# Altium schematic sheet SCH.SchDoc
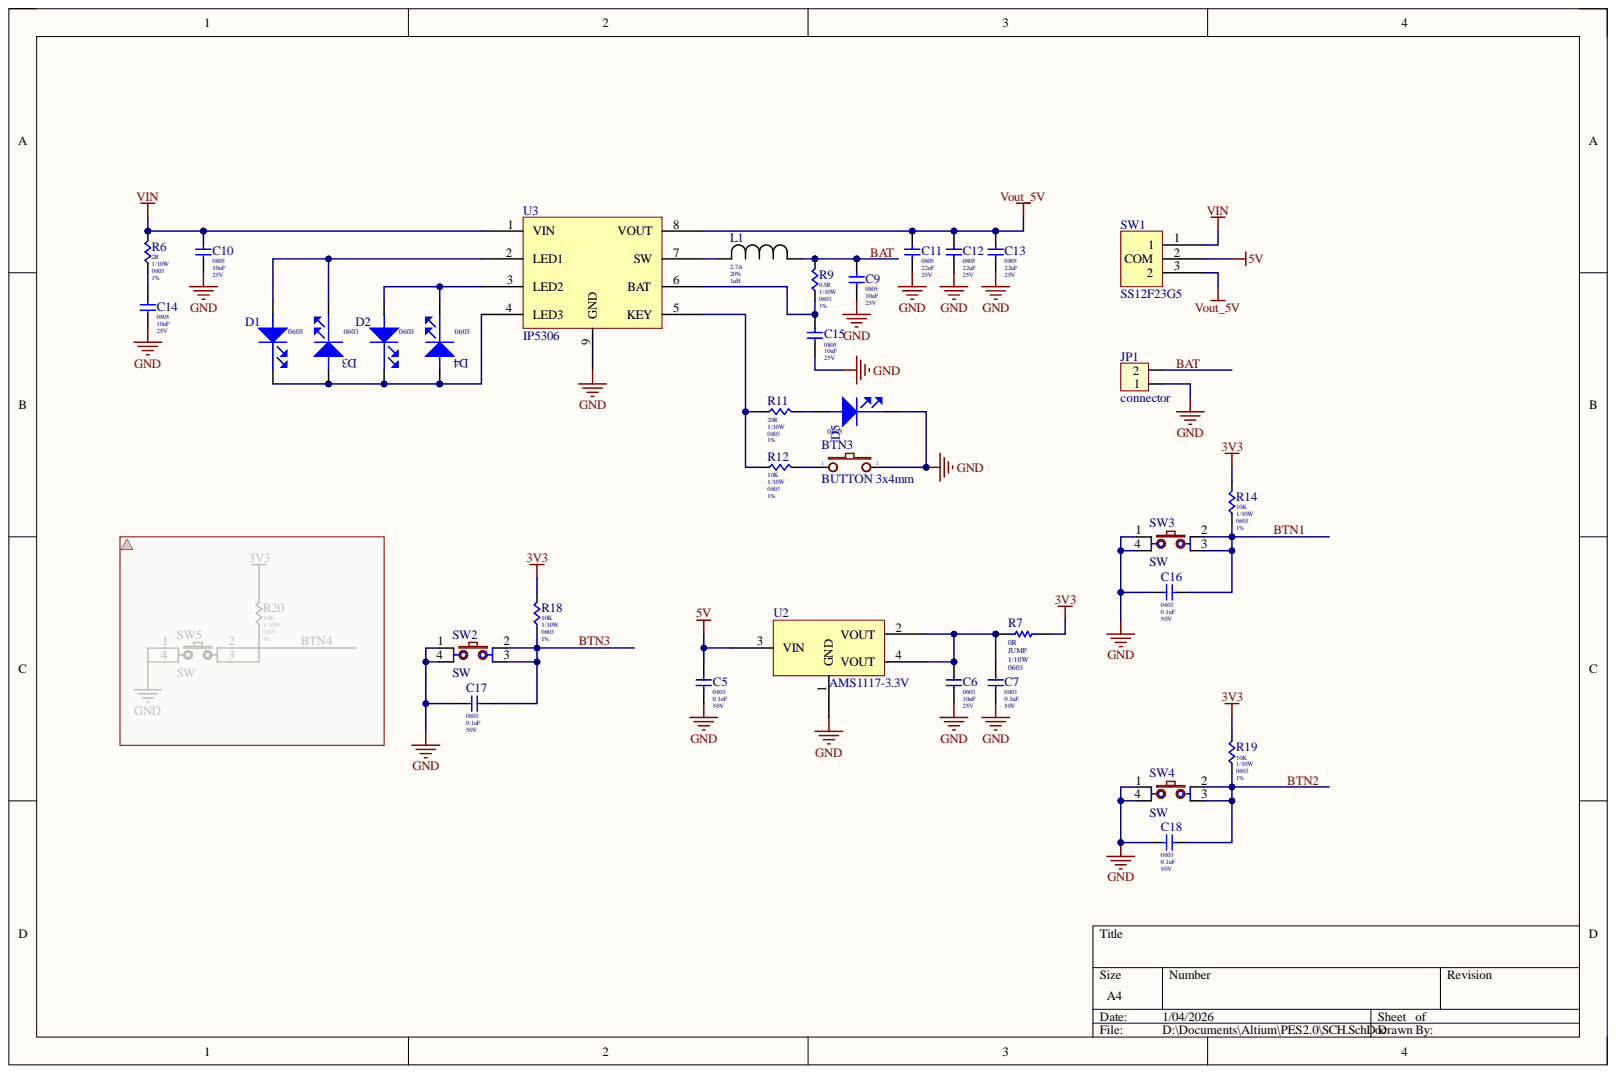
[diagram p0: SCH.SchDoc]

## Parts
BTN3  BUTTON 3x4mm  [BUTTON 3x4mm]
C5  value 0.1uF  Package: 0603  Voltage: 50V  [Cap_0603]  (hidden comment: CL10B104KB8NNNC)
C6  value 10uF  Package: 0603  Voltage: 25V  [Cap_0603]  (hidden comment: CL10A106MA8NRNC)
C7  value 0.1uF  Package: 0603  Voltage: 50V  [Cap_0603]  (hidden comment: CL10B104KB8NNNC)
C9  value 10uF  Package: 0805  Voltage: 25V  [Cap_0805]  (hidden comment: CL21A106KAYNNNE)
C10  value 10uF  Package: 0805  Voltage: 25V  [Cap_0805]  (hidden comment: CL21A106KAYNNNE)
C11  value 22uF  Package: 0805  Voltage: 25V  [Cap_0805]  (hidden comment: CL21A226MAYNNNE)
C12  value 22uF  Package: 0805  Voltage: 25V  [Cap_0805]  (hidden comment: CL21A226MAYNNNE)
C13  value 22uF  Package: 0805  Voltage: 25V  [Cap_0805]  (hidden comment: CL21A226MAYNNNE)
C14  value 10uF  Package: 0805  Voltage: 25V  [Cap_0805]  (hidden comment: CL21A106KAYNNNE)
C15  value 10uF  Package: 0805  Voltage: 25V  [Cap_0805]  (hidden comment: CL21A106KAYNNNE)
C16  value 0.1uF  Package: 0603  Voltage: 50V  [Cap_0603]  (hidden comment: CL10B104KB8NNNC)
C17  value 0.1uF  Package: 0603  Voltage: 50V  [Cap_0603]  (hidden comment: CL10B104KB8NNNC)
C18  value 0.1uF  Package: 0603  Voltage: 50V  [Cap_0603]  (hidden comment: CL10B104KB8NNNC)
D1  Package: 0603  [LED_0603_GREEN]  (hidden comment: 150060GS75000)
D2  Package: 0603  [LED_0603_GREEN]  (hidden comment: 150060GS75000)
D3  Package: 0603  [LED_0603_GREEN]  (hidden comment: 150060GS75000)
D4  Package: 0603  [LED_0603_GREEN]  (hidden comment: 150060GS75000)
D5  Package: 0603  [LED_0603_GREEN]  (hidden comment: 150060GS75000)
JP1  connector  [CON2]
L1  Current: 2.7A  Inductance: 1uH  Tolerance: 20%  [IND_CD43 4532-1R0]  (hidden comment: CD43 4532-1R0)
R6  value 2R  Pakage: 0603  Power: 1/10W  Tolerance: 1%  [RESC1608X05N]  (hidden comment: RMCF0603FT2R00)
R7  Resistance: 0R  Tolerance: JUMP  [RESC1608X05N]  (hidden comment: RC0603JR-070RL)
R9  0.5R  Pakage: 0603  Power: 1/10W  Tolerance: 1%  [RESC1608X05N]
R11  value 20R  Pakage: 0603  Power: 1/10W  [RESC1608X05N]  (hidden comment: RMCF0603FT20R0)
R12  value 10K  Package: 0603  Power: 1/10W  Tolerance: 1%  [RESC1608X05N]  (hidden comment: RC0603FR-0710KL)
R14  value 10K  Package: 0603  Power: 1/10W  Tolerance: 1%  [RESC1608X05N]  (hidden comment: RC0603FR-0710KL)
R18  value 10K  Package: 0603  Power: 1/10W  Tolerance: 1%  [RESC1608X05N]  (hidden comment: RC0603FR-0710KL)
R19  value 10K  Package: 0603  Power: 1/10W  Tolerance: 1%  [RESC1608X05N]  (hidden comment: RC0603FR-0710KL)
SW1  SS12F23G5  [SW_SS12F23G5]
SW2  SW  [SW2-SMD]
SW3  SW  [SW2-SMD]
SW4  SW  [SW2-SMD]
U2  AMS1117-3.3V  [SOT223]
U3  IP5306  [IC_IP5306]

## Nets
3V3: R7.2 R14.2 R18.2 R19.2
5V: C5.2 SW1.2 U2.3(VIN)
GND: BTN3.2 C5.1 C6.1 C7.1 C9.1 C10.1 C11.1 C12.1 C13.1 C14.1 C15.1 C16.2 C17.2 C18.2 D5.1 JP1.1 SW2.1 SW2.4 SW3.1 SW3.4 SW4.1 SW4.4 U2.1(GND) U3.9(GND)
VIN: C10.2 R6.2 SW1.1 U3.1(VIN)
Vout_5V: C11.2 C12.2 C13.2 SW1.3 U3.8(VOUT)
BAT: C9.2 JP1.2 L1.1 R9.2
BTN1: C16.1 R14.1 SW3.2 SW3.3
BTN2: C18.1 R19.1 SW4.2 SW4.3
BTN3: C17.1 R18.1 SW2.2 SW2.3
NetBTN3_1: BTN3.1 R12.2
NetC6_2: C6.2 C7.2 R7.1 U2.2(VOUT) U2.4(VOUT)
NetC14_2: C14.2 R6.1
NetC15_2: C15.2 R9.1 U3.6(BAT)
NetD1_1: D1.1 D2.1 D3.2 D4.2 U3.4(LED3)
NetD1_2: D1.2 D3.1 U3.2(LED1)
NetD2_2: D2.2 D4.1 U3.3(LED2)
NetD5_2: D5.2 R11.2
NetL1_2: L1.2 U3.7(SW)
NetR11_1: R11.1 R12.1 U3.5(KEY)

## Library data of the parts
C5 C7 C16-C18 = Cap Cer_0.1uF_50V_X7R_0603: CAP CER 0.1UF 50V X7R 0603; Component Kind: Standard; ComponentLink1Description: CXT; ComponentLink1URL: https://linhkien.cxtvn.com/1981-tu-0603-khong-phan-cuc.html; ComponentLink2Description: DIGIKEY; ComponentLink2URL: https://www.digikey.com/en/products/detail/samsung-electro-mechanics/CL10B104KB8NNNC/3886658; Library Name: HungKings.IntLib
C6 = Cap Cer_10uF_25V_X5R_0603: CAP CER 10UF 25V X5R 0603; Component Kind: Standard; ComponentLink1Description: CXT; ComponentLink1URL: https://linhkien.cxtvn.com/2886-tu-0603-khong-phan-cuc.html; ComponentLink2Description: DIGIKEY; ComponentLink2URL: https://www.digikey.com/en/products/detail/samsung-electro-mechanics/CL10A106MA8NRNC/3887527; Library Name: HungKings.IntLib
C9 C10 C14 C15 = Cap Cer_10uF_25V_X5R_0805: CAP CER 10UF 25V X5R 0805; Component Kind: Standard; ComponentLink1Description: CXT; ComponentLink1URL: https://linhkien.cxtvn.com/1978-tu-khong-phan-cuc-0805.html; ComponentLink2Description: DIGIKEY; ComponentLink2URL: https://www.digikey.bg/en/products/detail/samsung-electro-mechanics/CL21A106KAYNNNE/3888549?s=N4IgTCBcDaIMIBkwEYCCyAMA2A0qgmgHLECiIAugL5A; Library Name: HungKings.IntLib
C11-C13 = Cap Cer_22uF_25V_X5R_0805: CAP CER 22UF 25V X5R 0805; Component Kind: Standard; ComponentLink1Description: LKCL; ComponentLink1URL: https://linhkienchatluong.vn/tu-dan-0805/tu-dan-0805-226-22uf-25v-20-10c_sp1293_ct16943.aspx; ComponentLink2Description: DIGIKEY; ComponentLink2URL: https://www.digikey.com/en/products/detail/samsung-electro-mechanics/CL21A226MAYNNNE/10479857; Library Name: HungKings.IntLib
D1-D5 = LED_0603_Green: LED YELLOW/GREEN CLEAR 0603 SMD; Manufacturer: Würth Elektronik; Component Kind: Standard; ComponentLink1Description: BLK; ComponentLink1URL: https://banlinhkien.com/led-0603-mau-xanh-la-green-10-chiec-p22456389.html; ComponentLink2Description: DIGIKEY; ComponentLink2URL: https://www.digikey.bg/en/products/detail/w%C3%BCrth-elektronik/150060GS75000/4489898; Manufacturer Part Number: 150060GS75000; Library Name: HungKings.IntLib
JP1 = Con2: connector 2; Component Kind: Standard; Library Name: My library altium.IntLib
L1 = IND_1uH_2.7A: IND 1uH 2.7A SMD; Component Kind: Standard; ComponentLink1Description: TGIC; ComponentLink1URL: https://linhkien.cxtvn.com/5848-cuon-cam-cd43.html; Dimensions: 4.5 x 4.0 x 3.2mm; Library Name: HungKings.IntLib
R6 = Res_2R_0603_1%_1/10W: RES 2 OHM 1% 1/10W 0603; Component Kind: Standard; ComponentLink1Description: LKD; ComponentLink1URL: https://banlinhkiendientu.vn/dien-tro-dan-0603-2r-1-day-50c/; ComponentLink2Description: DIGIKEY; ComponentLink2URL: https://www.digikey.bg/en/products/detail/stackpole-electronics-inc/RMCF0603FT2R00/1761164; Library Name: HungKings.IntLib
R7 = Res_0R_Jumper _0603_1/10W: RES 0 OHM JUMPER 1/10W 0603; Manufacture 1: YAGEOWalsin Technology Corporation; Mouting Type: SMD; Temp Coef: ±100ppm/°C; Component Kind: Standard; ComponentLink1Description: CXT; ComponentLink1URL: https://linhkien.cxtvn.com/3833-dien-tro-0603-1-thong-so-tu-0r-den-910r.html; ComponentLink2Description: DIGIKEY; ComponentLink2URL: https://www.digikey.com/en/products/detail/yageo/RC0603JR-070RL/726675; Manufacture Part Number 1: RC0603JR-070RL; MSL: 1; Package: 0603; Power: 1/10W; Temperature: -55°C ~ 155°C; Type: RES; Library Name: HungKings.IntLib
R9 = Res_0.5R_0603_1%_1/10W: RES 0.5 OHM 1% 1/10W 0603; Component Kind: Standard; ComponentLink1Description: TGIC; ComponentLink1URL: https://www.thegioiic.com/dien-tro-0-5-ohm-0603-1-; Value: 0.5R; Library Name: HungKings.IntLib
R11 = Res_20R_0603_1%_1/10W: RES 20 OHM 1% 1/10W 0603; Component Kind: Standard; ComponentLink1Description: CXT; ComponentLink1URL: https://linhkienchatluong.vn/dien-tro-dan-0603-116w-1/dien-tro-dan-0603-20r-1-30x-50c_sp836_ct5735.aspx; ComponentLink2Description: DIGIKEY; ComponentLink2URL: https://www.digikey.bg/en/products/detail/stackpole-electronics-inc/RMCF0603FT20R0/1760803; Tolerance: 1%; Library Name: HungKings.IntLib
R12 R14 R18 R19 = Res_10K_0603_1%_1/10W: RES 10K OHM 1% 1/10W 0603; Component Kind: Standard; ComponentLink1Description: CXT; ComponentLink1URL: https://linhkien.cxtvn.com/1422-dien-tro-0603-1-thong-so-tu-1k-den-91k.html; ComponentLink2Description: DIGIKEY; ComponentLink2URL: https://www.digikey.com/en/products/detail/yageo/RC0603FR-0710KL/726880?s=N4IgTCBcDaIEoGEAMA2JBmAYnAtEg7AIxIDSAMiALoC%2BQA; Library Name: HungKings.IntLib
SW1 = SW_SS12F23G5: Component Kind: Standard; Library Name: HungKings.IntLib
SW2-SW4 = SW4: Switch button 4 pin; Value: Button chip 4 pin; Component Kind: Standard; Library Name: PES_Hung.IntLib
U2 = IC_AMS1117-3.3V: Linear Voltage Regulator IC Positive Fixed 1 Output 1A SOT-223-3L; Sort: LDO; Vin Max: 15V; Vout: 3.3; Component Kind: Standard; ComponentLink1Description: BLK; ComponentLink1URL: https://banlinhkien.com/ams11173.3v-sot223-p6651251.html; Current Max: 1A; Library Name: MS-Electronic.SchLib; Package: SOT-223
U3 = IC_IP5306: IC Battery Management SOP-8; Component Kind: Standard; ComponentLink1Description: CXT; ComponentLink1URL: https://linhkien.cxtvn.com/2878-ip5306-sop-8.html; Library Name: HungKings.IntLib
BTN3 = BUTTON 3x4mm: Component Kind: Standard; Library Name: HungKings.IntLib
